# Altium schematic sheet S7CAS-PLEIADES-CARTE-MERE_P02_POWER_CARRIER.SchDoc
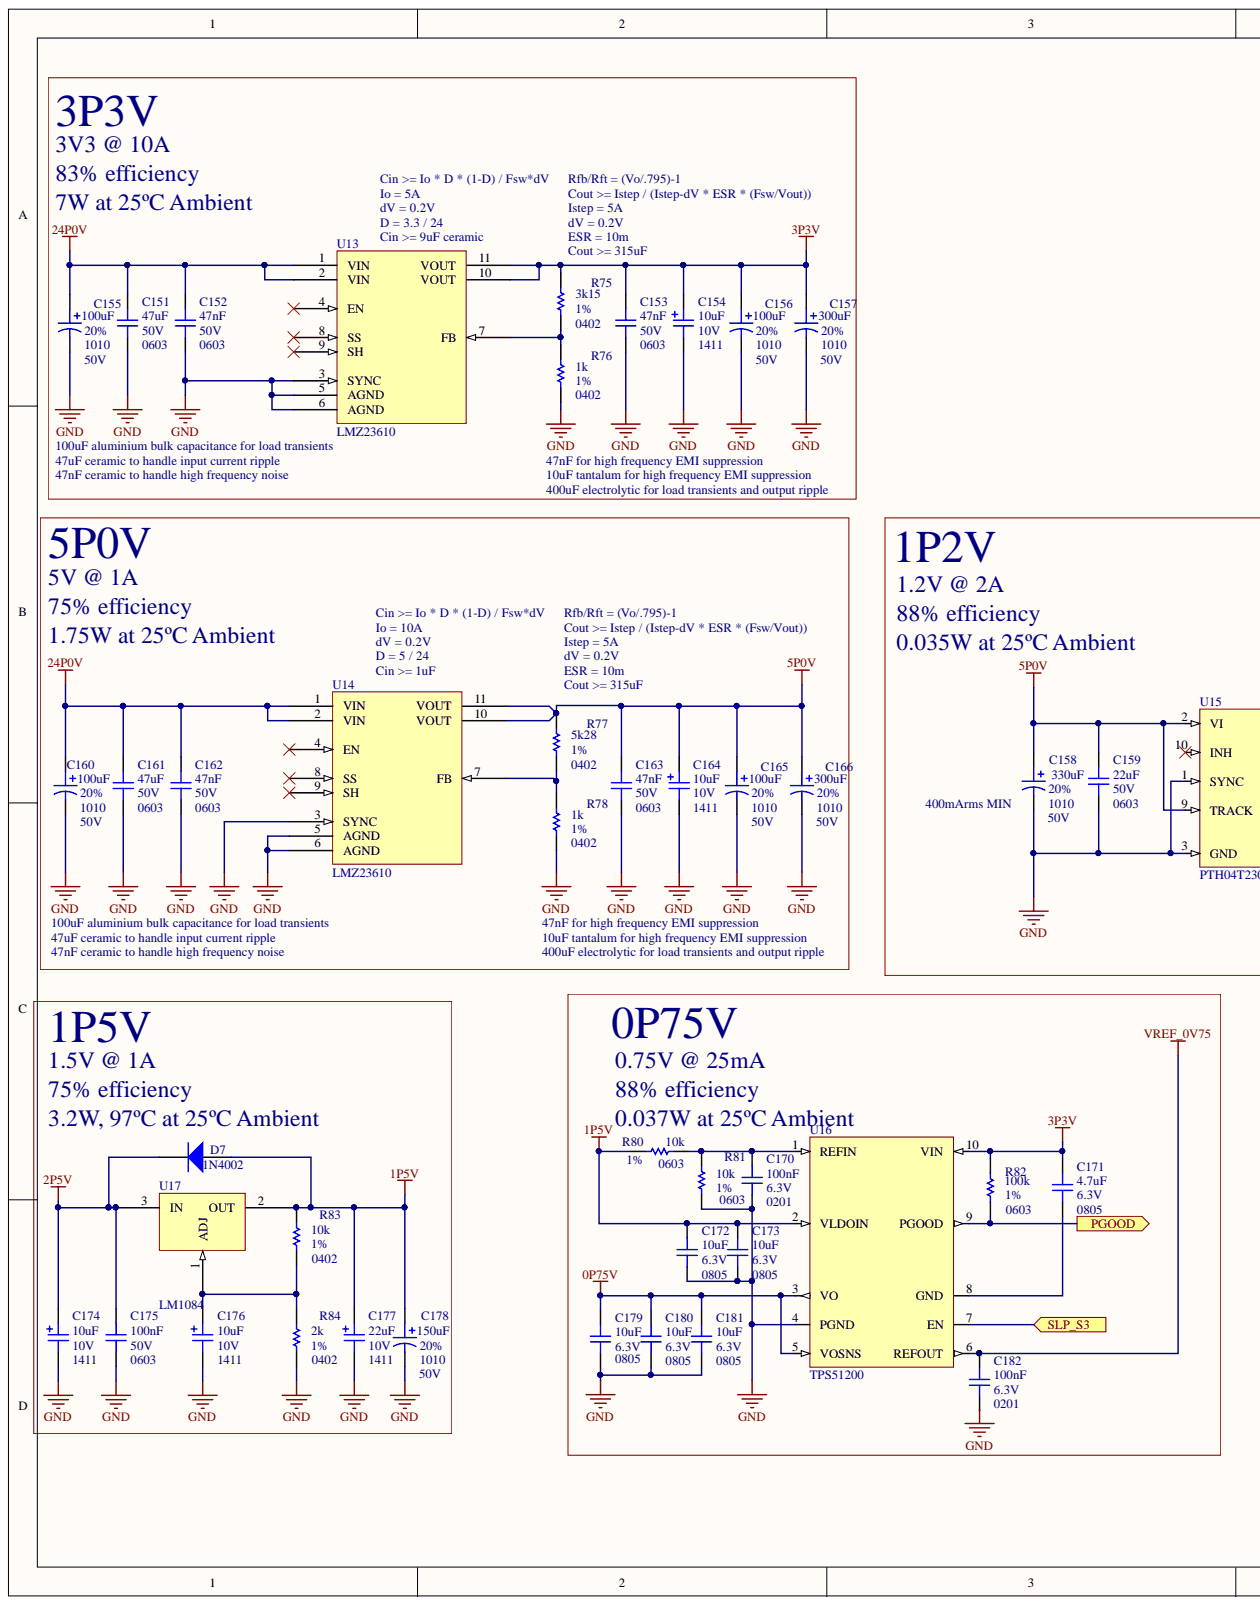
[diagram p0: S7CAS-PLEIADES-CARTE-MERE_P02_POWER_CARRIER.SchDoc, left]
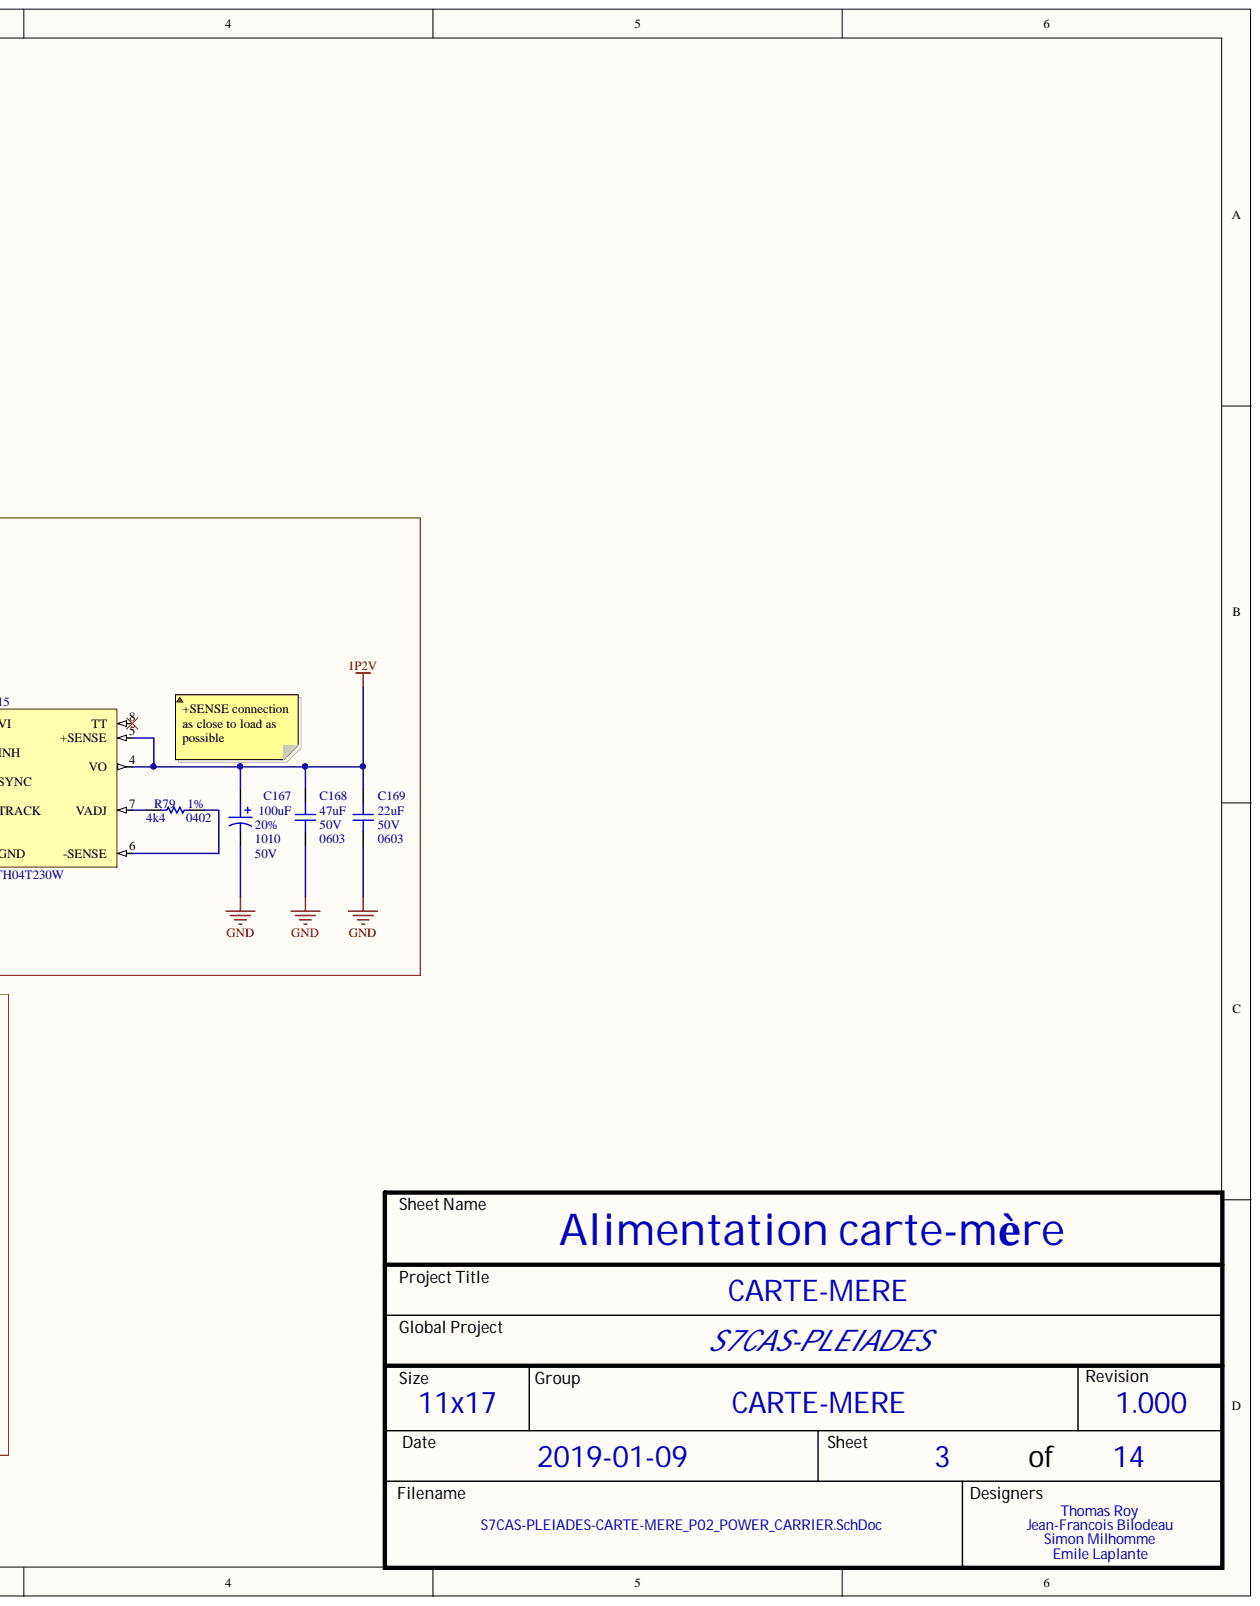
[diagram p1: S7CAS-PLEIADES-CARTE-MERE_P02_POWER_CARRIER.SchDoc, right]

## Parts
C151  value 47uF  Package: 0603  Voltage: 50V  [CAP_0603]  (hidden comment: CAP - 0603)
C152  value 47nF  Package: 0603  Voltage: 50V  [CAP_0603]  (hidden comment: CAP - 0603)
C153  value 47nF  Package: 0603  Voltage: 50V  [CAP_0603]  (hidden comment: CAP - 0603)
C154  value 10uF  Package: 1411  Voltage: 10V  [CAP_TAN_1411(B)]  (hidden comment: CAP - TANTALUM - CASE B)
C155  value 100uF  Package: 1010  Precision: 20%  Voltage: 50V  [CAP_ALU_1010_vishay]  (hidden comment: MAL215099101E3)
C156  value 100uF  Package: 1010  Precision: 20%  Voltage: 50V  [CAP_ALU_1010_vishay]  (hidden comment: MAL215099101E3)
C157  value 300uF  Package: 1010  Precision: 20%  Voltage: 50V  [CAP_ALU_1010_vishay]  (hidden comment: MAL215099101E3)
C158  value 330uF  Package: 1010  Precision: 20%  Voltage: 50V  [CAP_ALU_1010_vishay]  (hidden comment: MAL215099101E3)
C159  value 22uF  Package: 0603  Voltage: 50V  [CAP_0603]  (hidden comment: CAP - 0603)
C160  value 100uF  Package: 1010  Precision: 20%  Voltage: 50V  [CAP_ALU_1010_vishay]  (hidden comment: MAL215099101E3)
C161  value 47uF  Package: 0603  Voltage: 50V  [CAP_0603]  (hidden comment: CAP - 0603)
C162  value 47nF  Package: 0603  Voltage: 50V  [CAP_0603]  (hidden comment: CAP - 0603)
C163  value 47nF  Package: 0603  Voltage: 50V  [CAP_0603]  (hidden comment: CAP - 0603)
C164  value 10uF  Package: 1411  Voltage: 10V  [CAP_TAN_1411(B)]  (hidden comment: CAP - TANTALUM - CASE B)
C165  value 100uF  Package: 1010  Precision: 20%  Voltage: 50V  [CAP_ALU_1010_vishay]  (hidden comment: MAL215099101E3)
C166  value 300uF  Package: 1010  Precision: 20%  Voltage: 50V  [CAP_ALU_1010_vishay]  (hidden comment: MAL215099101E3)
C167  value 100uF  Package: 1010  Precision: 20%  Voltage: 50V  [CAP_ALU_1010_vishay]  (hidden comment: MAL215099101E3)
C168  value 47uF  Package: 0603  Voltage: 50V  [CAP_0603]  (hidden comment: CAP - 0603)
C169  value 22uF  Package: 0603  Voltage: 50V  [CAP_0603]  (hidden comment: CAP - 0603)
C170  value 100nF  Package: 0201  Voltage: 6.3V  [CAP_0201]  (hidden comment: CAP - 0201)
C171  value 4.7uF  Package: 0805  Voltage: 6.3V  [CAP_0805]  (hidden comment: CAP - 0805)
C172  value 10uF  Package: 0805  Voltage: 6.3V  [CAP_0805]  (hidden comment: CAP - 0805)
C173  value 10uF  Package: 0805  Voltage: 6.3V  [CAP_0805]  (hidden comment: CAP - 0805)
C174  value 10uF  Package: 1411  Voltage: 10V  [CAP_TAN_1411(B)]  (hidden comment: CAP - TANTALUM - CASE B)
C175  value 100nF  Package: 0603  Voltage: 50V  [CAP_0603]  (hidden comment: CAP - 0603)
C176  value 10uF  Package: 1411  Voltage: 10V  [CAP_TAN_1411(B)]  (hidden comment: CAP - TANTALUM - CASE B)
C177  value 22uF  Package: 1411  Voltage: 10V  [CAP_TAN_1411(B)]  (hidden comment: CAP - TANTALUM - CASE B)
C178  value 150uF  Package: 1010  Precision: 20%  Voltage: 50V  [CAP_ALU_1010_vishay]  (hidden comment: MAL215099101E3)
C179  value 10uF  [CAP_0805]  (hidden comment: CAP - 0805)
C180  value 10uF  Package: 0805  Voltage: 6.3V  [CAP_0805]  (hidden comment: CAP - 0805)
C181  value 10uF  Package: 0805  Voltage: 6.3V  [CAP_0805]  (hidden comment: CAP - 0805)
C182  value 100nF  Package: 0201  Voltage: 6.3V  [CAP_0201]  (hidden comment: CAP - 0201)
D7  1N4002  [DO-41]
R75  value 3k15  Package: 0402  Precision: 1%  [RES_0402]  (hidden comment: RES - 0402)
R76  value 1k  Package: 0402  Precision: 1%  [RES_0402]  (hidden comment: RES - 0402)
R77  value 5k28  Package: 0402  Precision: 1%  [RES_0402]  (hidden comment: RES - 0402)
R78  value 1k  Package: 0402  Precision: 1%  [RES_0402]  (hidden comment: RES - 0402)
R79  value 4k4  Package: 0402  Precision: 1%  [RES_0402]  (hidden comment: RES - 0402)
R80  value 10k  Package: 0603  Precision: 1%  [RES_0603]  (hidden comment: RES - 0603)
R81  value 10k  Package: 0603  [RES_0603]  (hidden comment: RES - 0603)
R82  value 100k  Package: 0603  Precision: 1%  [RES_0603]  (hidden comment: RES - 0603)
R83  value 10k  Package: 0402  Precision: 1%  [RES_0402]  (hidden comment: RES - 0402)
R84  value 2k  Precision: 1%  [RES_0402]  (hidden comment: RES - 0402)
U13  LMZ23610  [NDY0011A]
U14  LMZ23610  [NDY0011A]
U15  PTH04T230W  [PTH04T230W]
U16  TPS51200  [VSON-10]
U17  LM1084  [LM1084-DPAK]

## Ports
PGOOD, SLP_S3

## Nets
0P75V: C179.2 C180.2 C181.2 U16.3(VO) U16.5(VOSNS)
1P2V: C167.1 C168.2 C169.2 U15.4(VO) U15.5(+SENSE)
1P5V: C172.2 C173.2 C177.2 C178.1 D7.1 R80.2 R83.2 U16.2(VLDOIN) U17.2
2P5V: C174.2 C175.2 D7.2 U17.3
3P3V: C153.2 C154.2 C156.1 C157.1 C171.2 R75.2 R82.2 U13.10(VOUT) U13.11(VOUT) U16.10(VIN)
5P0V: C158.1 C159.2 C163.2 C164.2 C165.1 C166.1 R77.2 U14.10(VOUT) U14.11(VOUT) U15.2(VI) U15.9(TRACK)
24P0V: C151.2 C152.2 C155.1 C160.1 C161.2 C162.2 U13.1(VIN) U13.2(VIN) U14.1(VIN) U14.2(VIN)
GND: C151.1 C152.1 C153.1 C154.1 C155.2 C156.2 C157.2 C158.2 C159.1 C160.2 C161.1 C162.1 C163.1 C164.1 C165.2 C166.2 C167.2 C168.1 C169.1 C170.1 C172.1 C173.1 C174.1 C175.1 C176.1 C177.1 C178.2 C179.1 C180.1 C181.1 R76.1 R78.1 R81.1 R84.1 U13.3(SYNC) U13.5(AGND) U13.6(AGND) U14.3(SYNC) U14.5(AGND) U14.6(AGND) U15.1(SYNC) U15.3(GND) U16.4(PGND)
VREF_0V75: C182.2 U16.6(REFOUT)
PGOOD: R82.1 U16.9(PGOOD) ; port PGOOD
SLP_S3: U16.7(EN) ; port SLP_S3
NetC170_2: C170.2 R80.1 R81.2 U16.1(REFIN)
NetC171_1: C171.1 U16.8(GND)
NetC176_2: C176.2 R83.1 R84.2 U17.1
NetR75_1: R75.1 R76.2 U13.7(FB)
NetR77_1: R77.1 R78.2 U14.7(FB)
NetR79_1: R79.1 U15.6(-SENSE)
NetR79_2: R79.2 U15.7(VADJ)

Not connected: C182 1; U13 4(EN) 8(SS) 9(SH); U14 4(EN) 8(SS) 9(SH); U15 8(TT) 10(INH)

## Library data of the parts
C151-C153 C159 C161-C163 C168 C169 C175 = CAP - 0603: Precision: 10%; Component Kind: Standard; Description: CAP - 0603; Library Name: S7CAS_Lib2019_REV1_00.IntLib; CreatedBy: Jonathan Bouchard
C154 C164 C174 C176 C177 = CAP_TANT_1411(B): CAP - TANTALUM - 1411; Precision: 10%; Component Kind: Standard; Library Name: S7CAS_Lib2019_REV1_00.IntLib; CreatedBy: Jonathan Bouchard
C155-C158 C160 C165-C167 C178 = CAP_ALU_MAL215099101E3: CAP - ALU 100uF 20% 50V - 1010; Current: 490mA; Mfg: Vishay Dale; Component Kind: Standard; HelpURL: http://www.vishay.com/docs/28395/150crz.pdf; Library Name: S7CAS_Lib2019_REV1_00.IntLib; Mfg Part Number: MAL215099101E3; Supplier 1: Digikey; Supplier Part Number 1: 4399PHDKR-ND; Temperature: -55°C to 125°C; CreatedBy: Jonathan Bouchard
C170 C182 = CAP - 0201: Precision: 10%; Component Kind: Standard; Description: CAP - 0201; Library Name: S7CAS_Lib2019_REV1_00.IntLib; CreatedBy: Jonathan Bouchard
C171-C173 C180 C181 = CAP - 0805: Precision: 10%; Component Kind: Standard; Description: CAP - 0805; Library Name: S7CAS_Lib2019_REV1_00.IntLib; CreatedBy: Jonathan Bouchard
C179 = CAP - 0805: Precision: 10%; Voltage: 6.3V; Component Kind: Standard; Description: CAP - 0805; Library Name: S7CAS_Lib2019_REV1_00.IntLib; Package: 0805; CreatedBy: Jonathan Bouchard
D7 = Diode 1N4002: 1 Amp General Purpose Rectifier; Code_JEDEC: DO-204-AL; Component Kind: Standard; ComponentLink1Description: Manufacturer Link; ComponentLink1URL: http://www.fairchildsemi.com/; ComponentLink2Description: Datasheet; ComponentLink2URL: http://www.fairchildsemi.com/ds/1N%2F1N4001.pdf; DatasheetVersion: 1998; LatestRevisionDate: 17-Jul-2002; LatestRevisionNote: Re-released for DXP Platform.; Library Name: Miscellaneous Devices.IntLib; PackageDescription: Diode, Axial; Body 5.2 x 2.7 mm (LxDia. max), Lead Dia. 0.86 mm (max); PackageReference: DO-41; PackageVersion: Aug-1999; Published: 8-Jun-2000; Signal Integrity: 1N4002; Simulation: 1N4002; Publisher: Altium Limited
R75-R79 R83 R84 = RES - 0402: Power: 1/16W; Voltage: 50V; Component Kind: Standard; Description: RES - 0402; Library Name: S7CAS_Lib2019_REV1_00.IntLib; CreatedBy: Jonathan Bouchard
  R84: Package: 0402
R81 = RES - 0603: Precision: 1%; Component Kind: Standard; Description: RES - 0603; Library Name: S7CAS_Lib2019_REV1_00.IntLib; CreatedBy: Jonathan Bouchard
U15 = PTH04T230W: XXX - Description - Package; GRAMS Lib Spec: 2.7; Component Kind: Standard; Library Name: lib_JF.SchLib
U16 = TPS51200: TPS51200  Sink and Source  DDR Termination  Regulator; Component Kind: Standard; Library Name: lib_Thom.SchLib
R80 R82 = RES - 0603: Component Kind: Standard; Description: RES - 0603; Library Name: S7CAS_Lib2019_REV1_00.IntLib; CreatedBy: Jonathan Bouchard
U13 U14 = LMZ23610: Component Kind: Standard; Library Name: lib_JF.SchLib
U17 = LM1084: Component Kind: Standard; Library Name: lib_JF.SchLib
